# Altium schematic sheet uC.SchDoc
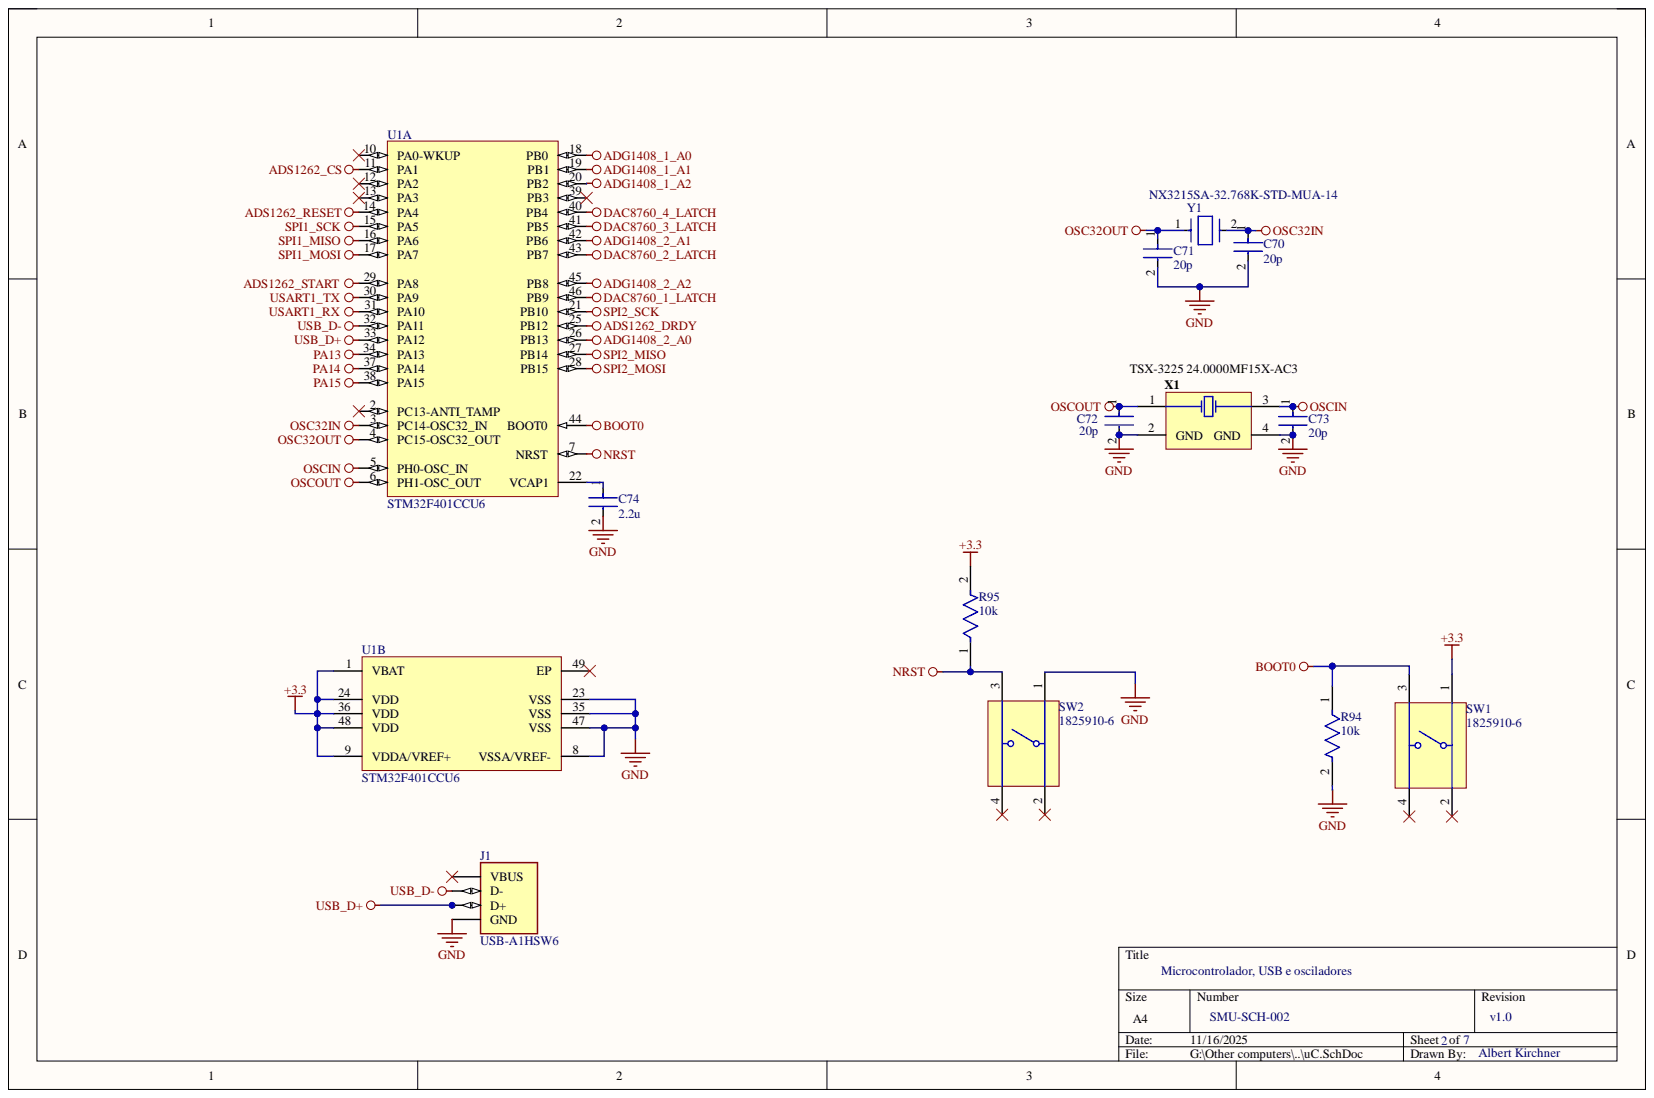
[diagram p0: uC.SchDoc]

## Parts
C70  20p  [FP-C1206C-EH-MFG]
C71  20p  [FP-C1206C-EH-MFG]
C72  20p  [FP-C1206C-EH-MFG]
C73  20p  [FP-C1206C-EH-MFG]
C74  2.2u  [FP-CL31-IPC_A]
J1  USB-A1HSW6  [OST_USB-A1HSW6]
R94  10k  [FP-CR1206-MFG]
R95  10k  [FP-CR1206-MFG]
SW1  1825910-6  [TECO-1825910-6_V]
SW2  1825910-6  [TECO-1825910-6_V]
U1  STM32F401CCU6  [STM-UFQFPN48_N]  (parts A,B)
X1  TSX-3225 24.0000MF15X-AC3  Package Reference: TSX-3225  [EPSON-TSX-3225_V]
Y1  NX3215SA-32.768K-STD-MUA-14  [FP-NX3215SA-MFG]

## Nets
+3.3: R95.2 SW1.1 U1.1(VBAT) U1.9(VDDA/VREF+) U1.24(VDD) U1.36(VDD) U1.48(VDD)
ADG1408_1_A0: U1.18(PB0)
ADG1408_1_A1: U1.19(PB1)
ADG1408_1_A2: U1.20(PB2)
ADG1408_2_A0: U1.26(PB13)
ADG1408_2_A1: U1.42(PB6)
ADG1408_2_A2: U1.45(PB8)
ADS1262_CS: U1.11(PA1)
ADS1262_DRDY: U1.25(PB12)
ADS1262_RESET: U1.14(PA4)
ADS1262_START: U1.29(PA8)
BOOT0: R94.1 SW1.3 U1.44(BOOT0)
DAC8760_1_LATCH: U1.46(PB9)
DAC8760_2_LATCH: U1.43(PB7)
DAC8760_3_LATCH: U1.41(PB5)
DAC8760_4_LATCH: U1.40(PB4)
GND: C70.2 C71.2 C72.2 C73.2 C74.2 J1.4(GND) R94.2 SW2.1 U1.8(VSSA/VREF-) U1.23(VSS) U1.35(VSS) U1.47(VSS) X1.2(GND) X1.4(GND)
NRST: R95.1 SW2.3 U1.7(NRST)
OSC32IN: C70.1 U1.3(PC14-OSC32_IN) Y1.2
OSC32OUT: C71.1 U1.4(PC15-OSC32_OUT) Y1.1
OSCIN: C73.1 U1.5(PH0-OSC_IN) X1.3
OSCOUT: C72.1 U1.6(PH1-OSC_OUT) X1.1
PA13: U1.34(PA13)
PA14: U1.37(PA14)
PA15: U1.38(PA15)
SPI1_MISO: U1.16(PA6)
SPI1_MOSI: U1.17(PA7)
SPI1_SCK: U1.15(PA5)
SPI2_MISO: U1.27(PB14)
SPI2_MOSI: U1.28(PB15)
SPI2_SCK: U1.21(PB10)
USART1_RX: U1.31(PA10)
USART1_TX: U1.30(PA9)
USB_D+: J1.3(D+) U1.33(PA12)
USB_D-: J1.2(D-) U1.32(PA11)
NetC74_1: C74.1 U1.22(VCAP1)

Not connected: J1 1(VBUS); SW1 2 4; SW2 2 4; U1 2(PC13-ANTI_TAMP) 10(PA0-WKUP) 12(PA2) 13(PA3) 39(PB3) 49(EP)

## Library data of the parts
C70-C73 = CMP-1037-05005-3: CAP CER 100UF 6.3V X5R 1206; Dielectric Material: Ceramic; Dissipation Factor: 5%; Tolerance: 2%; Capacitance: 100uF; Case Code (Imperial): 1206; Case Code (Metric): 3216; Case/Package: 1206; Component Kind: Standard; Construction: Flat; Depth: 1.6mm; Dielectric: X5R; Height: 1.6mm; Lead Free: Lead Free; Length: 3.2mm; Library Name: Altium Content Vault; Max Operating Temperature: 85°C; Min Operating Temperature: -55°C; Mount: Surface Mount; Number of Pins: 2; Package Quantity: 1; Packaging: Tape and Reel; Radiation Hardening: No; REACH SVHC: No SVHC; RoHS Compliant: Yes; Series: C; Termination: SMD/SMT; Thickness: 0.071inch; Voltage: 6.3V; Voltage Rating: 6.3V; Voltage Rating (DC): 6.3V; Width: 0.063inch
C74 = CMP-13271-001523-1: Cap Ceramic 22uF 6.3V X5R ±10% SMD 1206 +85°C Embossed T/R; Capacitance: 22uF; Case Code (Imperial): 1206; Case Code (Metric): 3216; Case/Package: 1206; Component Kind: Standard; Depth: 1.6mm; Dielectric: X5R; Height: 1.6mm; Length: 3.2mm; Library Name: Altium Content Vault; Material: Ceramic; Max Operating Temperature: 85°C; Min Operating Temperature: -55°C; Mount: Surface Mount; Package Quantity: 1; Packaging: Tape and Reel; Radiation Hardening: No; Thickness: 0.071inch; Tolerance: 10%; Voltage: 6.3V; Voltage Rating: 6.3V; Width: 0.063inch
J1 = USB-A1HSW6: MF: {'manufacturer': u'On Shore Technology Inc.'}; Availability: Unavailable; Component Kind: Standard; Library Name: USB-A1HSW6.SchLib; MP: USB-A1HSW6; Package: None; Price: None
R94 R95 = CMP-07231-003158-1: Thick Film Chip Resistors 1206 330Ω 0.25W 1% 100ppm/°C; Max Power Dissipation: 250mW; Resistance: 330R; Voltage Rating: 200V; Case Code (Imperial): 1206; Case Code (Metric): 3216; Case/Package: 1206; Component Kind: Standard; Composition: Thick Film; Contact Plating: Tin; Depth: 1.6mm; Height: 0.6mm; Length: 3.2mm; Library Name: Altium Content Vault; Max Operating Temperature: 155°C; Military Standard: Not; Min Operating Temperature: -55°C; Mount: Surface Mount; Number of Pins: 2; Number of Terminations: 2; Package Quantity: 5000; Packaging: Tape and Reel; Power Rating: 250mW; Radiation Hardening: No; REACH SVHC: No SVHC; Temperature Coefficient: 100ppm/°C; Termination: SMD/SMT; Thickness: 610um; Tolerance: 1%; Voltage Rating (DC): 200V; Width: 1.6mm
SW1 SW2 = CMP-1684-00021-1: Tact Switch, SPST-NO, 0.05 A, -35 to 85 degC, 4-Pin THD, RoHS, Bulk; Manufacturer: TE Connectivity; Actuator Color: Black; Actuator Length: 1.4mm; Actuator Material: PolybutyleneTerephthalate; Actuator Style: RoundButton; Body Orientation: Straight; Bushing Type: Unthreaded; Contact Rating @ Voltage: 0.05A@24VDC; Dielectric Strength: 500VAC; Electrical Life: 100000Cycles; Function: MomentaryContact; Illumination: N/R; Maximum Contact Resistance: 100mOhm; Maximum DC Voltage Rating: 24V; Pole Throw Configuration: SPST; Switch Configuration: OFF(ON); Base Material: N/A; Component Kind: Standard; Contact Material: CopperAlloy; Contact Plating: Silver; Datasheet URL: http://www.te.com/commerce/DocumentDelivery/DDEController?Action=showdoc&DocId=Customer+Drawing%7F1825910%7FC9%7Fpdf%7FEnglish%7FENG_CD_1825910_C9.pdf%7F1825910-6; Datasheet Version: Rev. C9; Dimension: 5.99x5mm; Family: 1825910; Housing Material: N/A; Library Name: Altium Content Vault; Manufacturer URL: http://www.te.com; Maximum AC Voltage Rating: N/A; Mechanical Life: 100000Cycles; Minimum Insulation Resistance: 100MOhm; Mounting: ThroughHole; Operating Temperature: -35to85C; Package Description: 4-Pin Through Hole Device, Body 5.99 x 5.99 mm, Pitch 6.5 mm; Package Reference: THD; Packaging: N/A; Part No: 1825910-6; Product Weight: N/A; RoHS: Yes; Seal Description: N/A; Seal Location: N/A; Terminal Type: PCPins; Thread Size: N/R
U1 = CMP-0243-00119-2: ARM Cortex-M4 32-bit MCU+FPU, 105 DMIPS, 256 kB Flash, 64 kB Internal RAM, 36 I/Os, 48-pin UFQFPN, -40 to 85 degC, Tray; Manufacturer: STMicroelectronics; FLASH Size (Prog) (kB): 256; I/Os (High Current): 36; Internal RAM Size (kB): 64; Operating Frequency(F) (Processor speed) (MHz): 84; Supply Voltage(Vcc) max (V): 3.6; Supply Voltage(Vcc) min (V): 1.8; Component Kind: Standard; ComponentLink1Description: Manufacturer URL; ComponentLink1URL: http://www.st.com/web/en/home.html; ComponentLink2Description: Datasheet; ComponentLink2URL: http://www.st.com/st-web-ui/static/active/en/resource/technical/document/data_brief/DM00071938.pdf; ComponentLink3Description: Package Specification; ComponentLink3URL: http://www.st.com/st-web-ui/static/active/en/resource/technical/document/data_brief/DM00071938.pdf; Core: ARM Cortex-M4; DatasheetVersion: Rev. 1; Library Name: Altium Content Vault; Mounting Technology: Surface Mount; PackageDescription: 48-Pin Ultra Thin Fine Pitch Quad Flat No-Lead, 7 x 7 x 0.6 mm Body, 0.5 mm Pitch, Exposed Pad; PackageReference: UFQFPN48; PackageVersion: Rev. 1, 04/2013; Packing: Tray; PartNumber: STM32F401CCU6
X1 = CMP-2000-07333-1: MHz Range Crystal, 24 MHz, -40 to 85 degC, 4-Pin SMD, RoHS, Tape and Reel; Manufacturer: Epson; Brand: EpsonTiming; Drive Level: 200uW; ESR: 40Ohms; Frequency: 24MHz; Frequency Stability: 10PPM; Load Capacitance: 9pF; Unit Weight: 0.000564oz; Component Kind: Standard; Datasheet URL: https://support.epson.biz/td/api/doc_check.php?dl=brief_FA-238V_en.pdf; Datasheet Version: 12/2015; Factory Pack Quantity: 250; Height: 0.6mm; Length: 3.2mm; Library Name: Altium Content Vault; Manufacturer URL: https://www.eea.epson.com/; Mounting Style: SMD/SMT; Mounting Technology: SurfaceMount; Operating Temperature Range: -40Cto+85C; Package / Case: 3.2mmx2.5mm; Package Description: 4-Pin Surface Mount Device, Body 3.2 x 2.5 mm; Packaging: Reel; Product Category: Crystals; RoHS: True; Series: TSX-3225; Termination Style: SMD/SMT; Tolerance: 10PPM; Type: Crystal; Width: 2.5mm
Y1 = CMP-00536-22488149-1: NX3215SA-32.768K-STD-MUA-14 Parallel - Fundamental Quartz Crystal, 0.032768MHz Nom; ESR (Equivalent Series Resistance): 70kΩ; Frequency: 32.768kHz; Frequency Tolerance: 0.002%; Load Capacitance: 6pF; Case/Package: SMD/SMT; Component Kind: Standard; Height: 889µm; Length: 3.2004mm; Library Name: Altium Content Vault; Max Operating Temperature: 85°C; Min Operating Temperature: -40°C; Mount: Surface Mount; NexarPartId: 22488149; Number of Pins: 2; Packaging: Tape & Reel (TR); RoHS: Compliant; Width: 1.4986mm
